# Altium schematic sheet PNPController.SchDoc(PNPController)
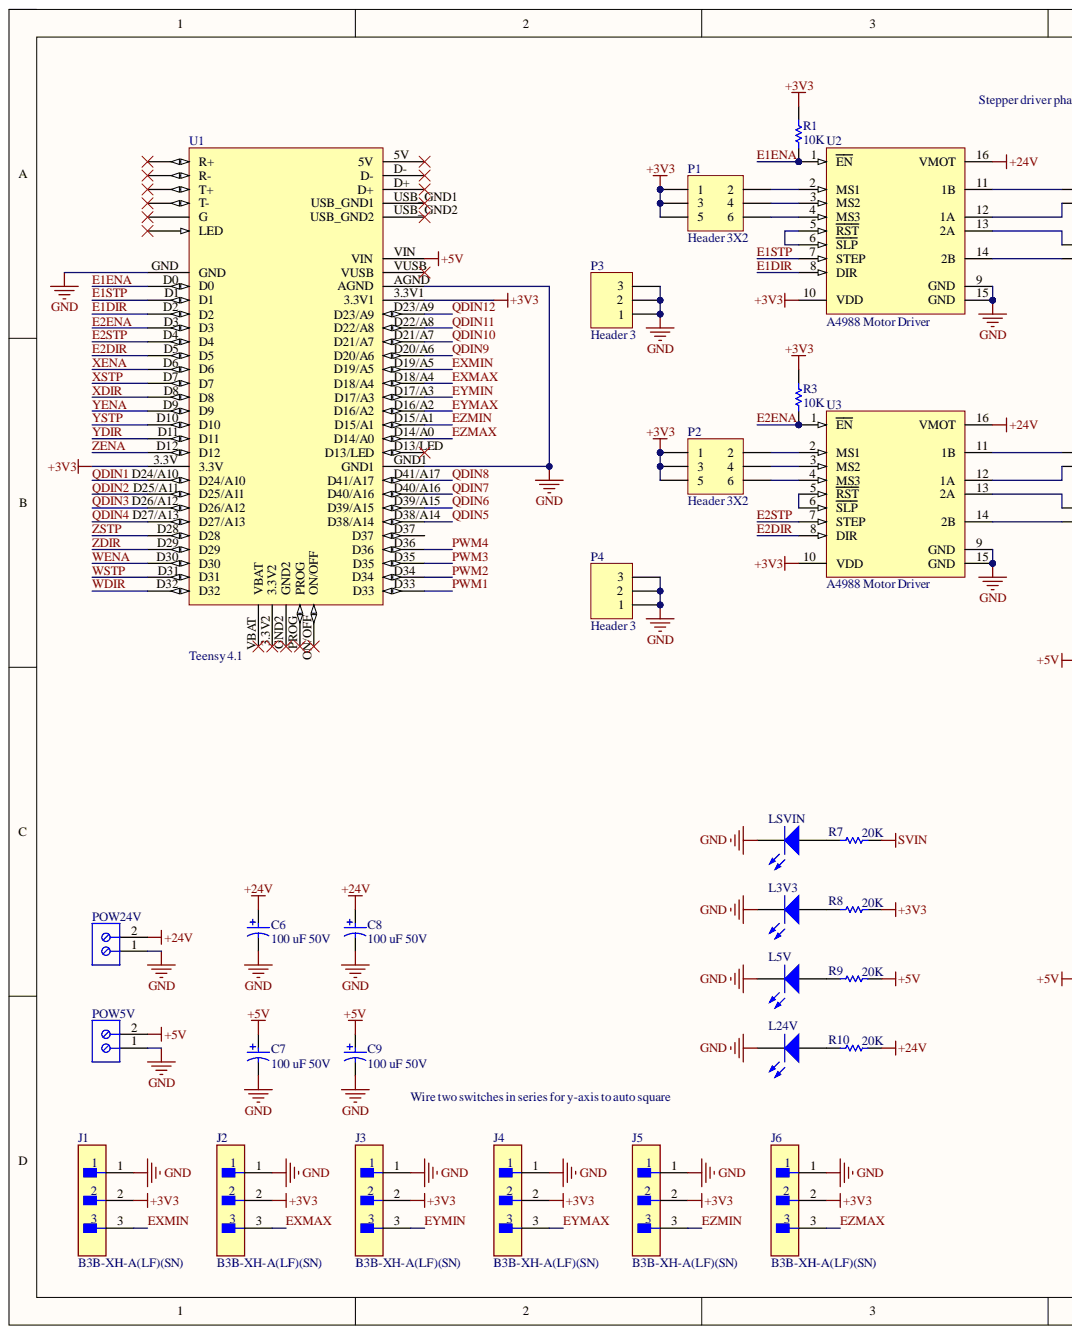
[diagram p0: PNPController.SchDoc, left]
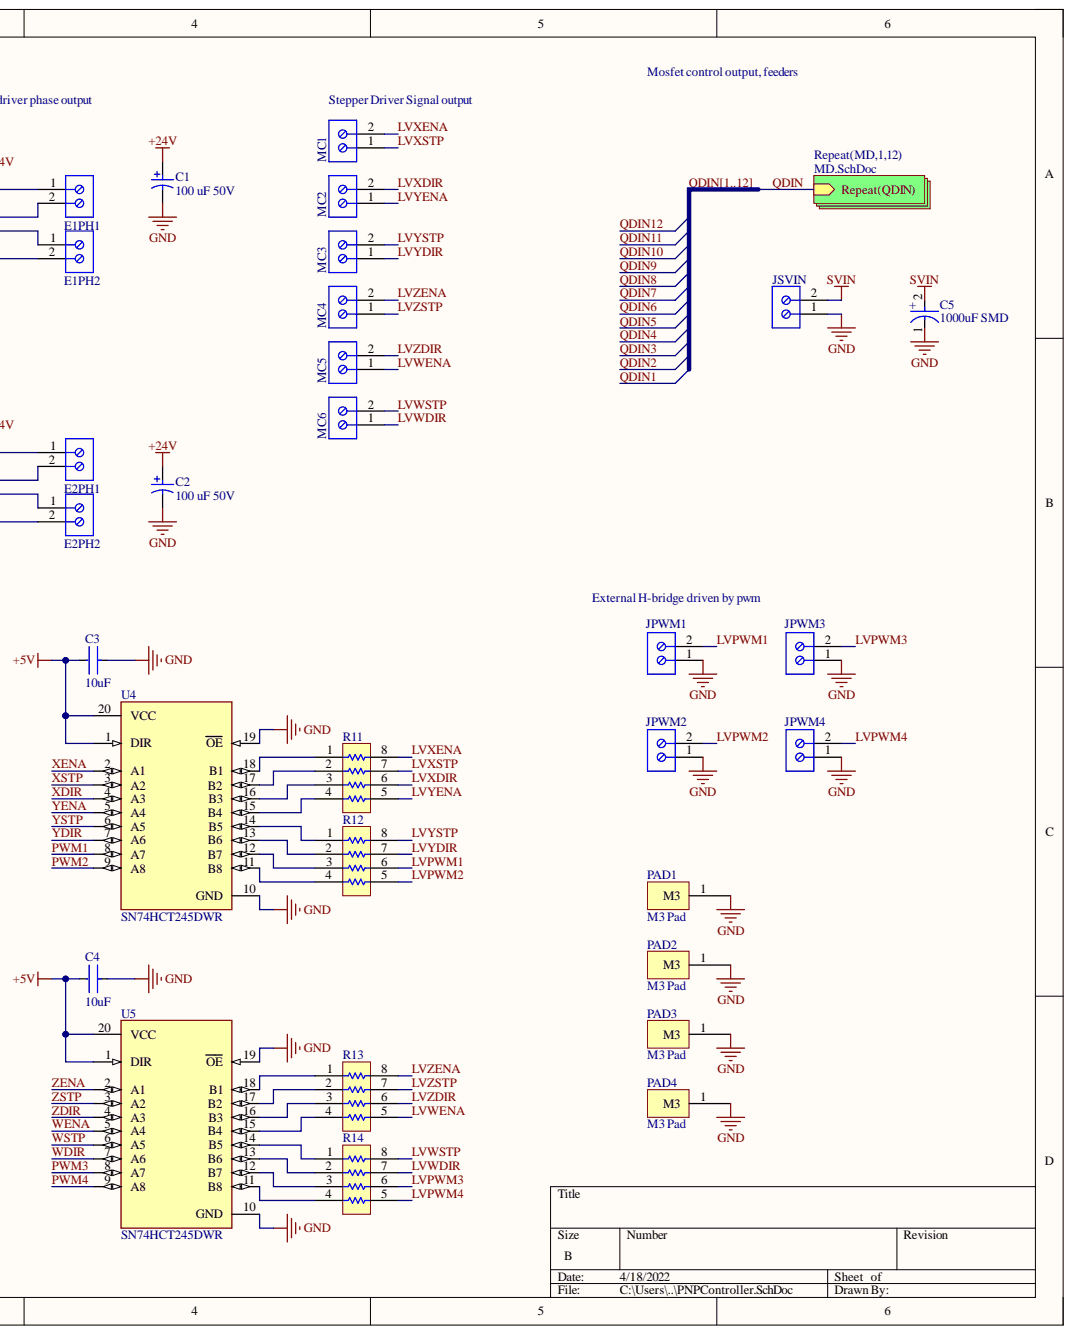
[diagram p1: PNPController.SchDoc, right]

## Parts
C1  100 uF 50V  [NIC-UWT1H101MNL1GS_L]
C2  100 uF 50V  [NIC-UWT1H101MNL1GS_L]
C3  value 10uF  [FP-CC0805-0_85-MFG]  (hidden comment: CC0805KKX7R6BB105)
C4  value 10uF  [FP-CC0805-0_85-MFG]  (hidden comment: CC0805KKX7R6BB105)
C5  1000uF SMD  value 1000uF  Capacitance: 1000uF  [FP-FK-J16-MFG]
C6  100 uF 50V  [NIC-UWT1H101MNL1GS_L]
C7  100 uF 50V  [NIC-UWT1H101MNL1GS_L]
C8  100 uF 50V  Rated Voltage: 50V  [NIC-UWT1H101MNL1GS_L]
C9  100 uF 50V  Rated Voltage: 50V  [NIC-UWT1H101MNL1GS_L]
E1PH1  Screw Terminal Block 2 Position  [TECO-282836-2_V]  (value not drawn)
E1PH2  Screw Terminal Block 2 Position  [TECO-282836-2_V]  (value not drawn)
E2PH1  Screw Terminal Block 2 Position  [TECO-282836-2_V]  (value not drawn)
E2PH2  Screw Terminal Block 2 Position  [TECO-282836-2_V]  (value not drawn)
J1  B3B-XH-A(LF)(SN)  [FP-B3B-XH-A_LF_SN-MFG]
J2  B3B-XH-A(LF)(SN)  [FP-B3B-XH-A_LF_SN-MFG]
J3  B3B-XH-A(LF)(SN)  [FP-B3B-XH-A_LF_SN-MFG]
J4  B3B-XH-A(LF)(SN)  [FP-B3B-XH-A_LF_SN-MFG]
J5  B3B-XH-A(LF)(SN)  [FP-B3B-XH-A_LF_SN-MFG]
J6  B3B-XH-A(LF)(SN)  [FP-B3B-XH-A_LF_SN-MFG]
JPWM1  Screw Terminal Block 2 Position  [TECO-282836-2_V]  (value not drawn)
JPWM2  Screw Terminal Block 2 Position  [TECO-282836-2_V]  (value not drawn)
JPWM3  Screw Terminal Block 2 Position  [TECO-282836-2_V]  (value not drawn)
JPWM4  Screw Terminal Block 2 Position  [TECO-282836-2_V]  (value not drawn)
JSVIN  Screw Terminal Block 2 Position  [TECO-282836-2_V]  (value not drawn)
L3V3  150080SS75000  [0805_A]  (value not drawn)
L5V  150080SS75000  [0805_A]  (value not drawn)
L24V  150080SS75000  [0805_A]  (value not drawn)
LSVIN  150080SS75000  [0805_A]  (value not drawn)
MC1  Screw Terminal Block 2 Position  [TECO-282836-2_V]  (value not drawn)
MC2  Screw Terminal Block 2 Position  [TECO-282836-2_V]  (value not drawn)
MC3  Screw Terminal Block 2 Position  [TECO-282836-2_V]  (value not drawn)
MC4  Screw Terminal Block 2 Position  [TECO-282836-2_V]  (value not drawn)
MC5  Screw Terminal Block 2 Position  [TECO-282836-2_V]  (value not drawn)
MC6  Screw Terminal Block 2 Position  [TECO-282836-2_V]  (value not drawn)
P1  Header 3X2  [HDR2X3]
P2  Header 3X2  [HDR2X3]
P3  Header 3  [HDR1X3]
P4  Header 3  [HDR1X3]
PAD1  M3 Pad  [M3 Pad-Footprint-1]
PAD2  M3 Pad  [M3 Pad-Footprint-1]
PAD3  M3 Pad  [M3 Pad-Footprint-1]
PAD4  M3 Pad  [M3 Pad-Footprint-1]
POW5V  Screw Terminal Block 2 Position  [TECO-282836-2_V]  (value not drawn)
POW24V  Screw Terminal Block 2 Position  [TECO-282836-2_V]  (value not drawn)
R1  value 10K  [RESC2013X50X30LL20T20]  (hidden comment: CRCW08050000Z0EA)
R3  value 10K  [RESC2013X50X30LL20T20]  (hidden comment: CRCW08050000Z0EA)
R7  value 20K  [RESC2013X50X30LL20T20]  (hidden comment: CRCW08050000Z0EA)
R8  value 20K  [RESC2013X50X30LL20T20]  (hidden comment: CRCW08050000Z0EA)
R9  value 20K  [RESC2013X50X30LL20T20]  (hidden comment: CRCW08050000Z0EA)
R10  value 20K  [RESC2013X50X30LL20T20]  (hidden comment: CRCW08050000Z0EA)
R11  CAT16-103J4LF  [BOUR-CAT16-103J4LF_L]  (value not drawn)
R12  CAT16-103J4LF  [BOUR-CAT16-103J4LF_L]  (value not drawn)
R13  CAT16-103J4LF  [BOUR-CAT16-103J4LF_L]  (value not drawn)
R14  CAT16-103J4LF  [BOUR-CAT16-103J4LF_L]  (value not drawn)
U1  Teensy 4.1  [DEV-16771]
U2  A4988 Motor Driver  [Polo-A4988 Motor Driver_V]
U3  A4988 Motor Driver  [Polo-A4988 Motor Driver_V]
U4  SN74HCT245DWR  [FP-DW0020A-MFG]
U5  SN74HCT245DWR  [FP-DW0020A-MFG]

## Nets
+3V3: J1.2 J2.2 J3.2 J4.2 J5.2 J6.2 P1.1 P1.3 P1.5 P2.1 P2.3 P2.5 R1.2 R3.2 R8.2 U1.3.3V U1.3.3V1 U2.10(VDD) U3.10(VDD)
+5V: C3.2 C4.2 C7.1 C9.1 POW5V.2 R9.2 U1.VIN U4.1(DIR) U4.20(VCC) U5.1(DIR) U5.20(VCC)
+24V: C1.1 C2.1 C6.1 C8.1 POW24V.2 R10.2 U2.16(VMOT) U3.16(VMOT)
GND: C1.2 C2.2 C3.1 C4.1 C5.1 C6.2 C7.2 C8.2 C9.2 J1.1 J2.1 J3.1 J4.1 J5.1 J6.1 JPWM1.1 JPWM2.1 JPWM3.1 JPWM4.1 JSVIN.1 L3V3.1 L5V.1 L24V.1 LSVIN.1 P3.1 P3.2 P3.3 P4.1 P4.2 P4.3 PAD1.1 PAD2.1 PAD3.1 PAD4.1 POW5V.1 POW24V.1 U1.AGND U1.GND U1.GND1 U2.9(GND) U2.15(GND) U3.9(GND) U3.15(GND) U4.10(GND) U4.19(OE) U5.10(GND) U5.19(OE)
SVIN: C5.2 JSVIN.2 R7.2
E1DIR: U1.D2 U2.8(DIR)
E1ENA: R1.1 U1.D0 U2.1(EN)
E1STP: U1.D1 U2.7(STEP)
E2DIR: U1.D5 U3.8(DIR)
E2ENA: R3.1 U1.D3 U3.1(EN)
E2STP: U1.D4 U3.7(STEP)
EXMAX: J2.3 U1.D18/A4
EXMIN: J1.3 U1.D19/A5
EYMAX: J4.3 U1.D16/A2
EYMIN: J3.3 U1.D17/A3
EZMAX: J6.3 U1.D14/A0
EZMIN: J5.3 U1.D15/A1
LVPWM1: JPWM1.2 R12.6
LVPWM2: JPWM2.2 R12.5
LVPWM3: JPWM3.2 R14.6
LVPWM4: JPWM4.2 R14.5
LVWDIR: MC6.1 R14.7
LVWENA: MC5.1 R13.5
LVWSTP: MC6.2 R14.8
LVXDIR: MC2.2 R11.6
LVXENA: MC1.2 R11.8
LVXSTP: MC1.1 R11.7
LVYDIR: MC3.1 R12.7
LVYENA: MC2.1 R11.5
LVYSTP: MC3.2 R12.8
LVZDIR: MC5.2 R13.6
LVZENA: MC4.2 R13.8
LVZSTP: MC4.1 R13.7
PWM1: U1.D33 U4.8(A7)
PWM2: U1.D34 U4.9(A8)
PWM3: U1.D35 U5.8(A7)
PWM4: U1.D36 U5.9(A8)
QDIN1: U1.D24/A10  [also labelled QDIN]
QDIN2: U1.D25/A11  [also labelled QDIN]
QDIN3: U1.D26/A12  [also labelled QDIN]
QDIN4: U1.D27/A13  [also labelled QDIN]
QDIN5: U1.D38/A14  [also labelled QDIN]
QDIN6: U1.D39/A15  [also labelled QDIN]
QDIN7: U1.D40/A16  [also labelled QDIN]
QDIN8: U1.D41/A17  [also labelled QDIN]
QDIN9: U1.D20/A6  [also labelled QDIN]
QDIN10: U1.D21/A7  [also labelled QDIN]
QDIN11: U1.D22/A8  [also labelled QDIN]
QDIN12: U1.D23/A9  [also labelled QDIN]
WDIR: U1.D32 U5.7(A6)
WENA: U1.D30 U5.5(A4)
WSTP: U1.D31 U5.6(A5)
XDIR: U1.D8 U4.4(A3)
XENA: U1.D6 U4.2(A1)
XSTP: U1.D7 U4.3(A2)
YDIR: U1.D11 U4.7(A6)
YENA: U1.D9 U4.5(A4)
YSTP: U1.D10 U4.6(A5)
ZDIR: U1.D29 U5.4(A3)
ZENA: U1.D12 U5.2(A1)
ZSTP: U1.D28 U5.3(A2)
NetE1PH1_1: E1PH1.1 U2.11(1B)
NetE1PH1_2: E1PH1.2 U2.12(1A)
NetE1PH2_1: E1PH2.1 U2.13(2A)
NetE1PH2_2: E1PH2.2 U2.14(2B)
NetE2PH1_1: E2PH1.1 U3.11(1B)
NetE2PH1_2: E2PH1.2 U3.12(1A)
NetE2PH2_1: E2PH2.1 U3.13(2A)
NetE2PH2_2: E2PH2.2 U3.14(2B)
NetL3V3_2: L3V3.2 R8.1
NetL5V_2: L5V.2 R9.1
NetL24V_2: L24V.2 R10.1
NetLSVIN_2: LSVIN.2 R7.1
NetP1_2: P1.2 U2.2(MS1)
NetP1_4: P1.4 U2.3(MS2)
NetP1_6: P1.6 U2.4(MS3)
NetP2_2: P2.2 U3.2(MS1)
NetP2_4: P2.4 U3.3(MS2)
NetP2_6: P2.6 U3.4(MS3)
NetR11_1: R11.1 U4.18(B1)
NetR11_2: R11.2 U4.17(B2)
NetR11_3: R11.3 U4.16(B3)
NetR11_4: R11.4 U4.15(B4)
NetR12_1: R12.1 U4.14(B5)
NetR12_2: R12.2 U4.13(B6)
NetR12_3: R12.3 U4.12(B7)
NetR12_4: R12.4 U4.11(B8)
NetR13_1: R13.1 U5.18(B1)
NetR13_2: R13.2 U5.17(B2)
NetR13_3: R13.3 U5.16(B3)
NetR13_4: R13.4 U5.15(B4)
NetR14_1: R14.1 U5.14(B5)
NetR14_2: R14.2 U5.13(B6)
NetR14_3: R14.3 U5.12(B7)
NetR14_4: R14.4 U5.11(B8)
NetU1_3.3V2: U1.3.3V2
NetU1_5V: U1.5V
NetU1_D13/LED: U1.D13/LED
NetU1_D37: U1.D37
NetU1_D+: U1.D+
NetU1_D-: U1.D-
NetU1_G: U1.G
NetU1_GND2: U1.GND2
NetU1_LED: U1.LED
NetU1_ON/OFF: U1.ON/OFF
NetU1_PROG: U1.PROG
NetU1_R+: U1.R+
NetU1_R-: U1.R-
NetU1_T+: U1.T+
NetU1_T-: U1.T-
NetU1_USB_GND1: U1.USB_GND1
NetU1_USB_GND2: U1.USB_GND2
NetU1_VBAT: U1.VBAT
NetU1_VUSB: U1.VUSB
NetU2_5: U2.5(RST) U2.6(SLP)
NetU3_5: U3.5(RST) U3.6(SLP)

## Library data of the parts
C1 C2 C6-C9 = CMP-2000-07373-1: Aluminum Electrolytic Capacitor, 100 uF, +/- 20%, 50 V, -55 to 105 degC, 2-Pin SMD, RoHS, Tape and Reel; Manufacturer: Nichicon; Capacitance: 100µF; Type: Can; Case Size/Dimension: Ø8x10mm; Component Kind: Standard; Datasheet URL: http://products.nichicon.co.jp/en/pdf/XJA043/e-uwt.pdf; Datasheet Version: 08/2015; Library Name: Altium Content Vault; Manufacturer URL: http://www.nichicon.co.jp/english/; Mounting Technology: SurfaceMount; Operating Temp-Max: 105°C; Package Description: Aluminum Electrolytic Capacitor, Body 8.3 x 8.3 mm, Height 10.8 mm; Package Reference: UWT1H101MNL1GS
  C1 C2 C6 C7: Rated Voltage: 50V
C3 C4 = CMP-03422-000182-1: CAP CER 1UF 10V X7R 0805; Manufacturer: Yageo; Material: Ceramic; Tolerance: 0.1; Automotive: No; Capacitance: 1 uF; Category: Cap; Component Kind: Standard; ComponentLink2Description: Datasheet; ComponentLink2URL: http://www.yageo.com/documents/recent/UPY-GPHC_Y5V_6.3V-to-50V_8.pdf; Device Class L1: Passive Components; Device Class L2: Capacitors; Device Class L3: Ceramic Capacitors; Digikey Description: CAP CER 1UF 10V X7R 0805; Footprint Url: http://www.yageo.com/exep/pages/download/literatures/UPY-C_GEN_24.pdf; Height: 0.95mm; Ipc Land Pattern Name: CAPC200125X85; Lead Free: Yes; Library Name: Altium Content Vault; Manufacturer Part Number: CC0805KKX7R6BB105; Mouser Description: Multilayer Ceramic Capacitors MLCC - SMD/SMT 1.0uF 10V X7R 10%; Octopart: https://octopart.com/cc0805kkx7r6bb105-yageo-42522859; Package: CC0805-0.85; RoHS: TRUE; Temperature: -30°C to +85°C; Temperature Characteristic: X7R; Temperature Range High: +85°C; Temperature Range Low: -30°C; Voltage Rating: 10 V
C5 = CMP-05427-000011-1: CAP ALUM 1000UF 20% 50V SMD; Manufacturer: Panasonic Electronic Components; Manufacturer Part Number: EEV-FK1H102M; Automotive Grade: Grade 2; Dissipation Factor: 10%; Esr: 0.073Ω; Leakage Current: 500uA; Life: 2000Hours; Material: Aluminum; Ripple Current: 1610mA; Automotive: Yes; Category: Cap; Component Kind: Standard; ComponentLink2Description: Datasheet; ComponentLink2URL: https://b2b-api.panasonic.eu/file_stream/pids/fileversion/3127; Device Class L1: Passive Components; Device Class L2: Capacitors; Device Class L3: Aluminum Electrolytic Capacitors; Digikey Description: CAP ALUM 1000UF 20% 50V SMD; Height: 17mm; Lead Free: Yes; Library Name: Altium Content Vault; Mouser Description: Cap Aluminum Lytic 1000uF 50V 20% (16 X 16.5mm) SMD 0.073 Ohm 1610mA 2000h 105C Automotive T/R; Octopart: https://octopart.com/eev-fk1h102m-panasonic-39480688; Package: FK-J16; RoHS: TRUE; Temperature: -55°C to +105°C; Temperature Range High: +105°C; Temperature Range Low: -55°C; Tolerance: 20%; Voltage Rating: 50V
E1PH1 E1PH2 E2PH1 E2PH2 JPWM1-JPWM4 JSVIN MC1-MC6 POW5V POW24V = CMP-002-00072-1: TERM BLK 2POS SIDE ENTRY 5MM PCB; Approvals: UL; Closed End: No (Without); Connector Type: Receptacle; Current: 13.5A; Interlock: Yes (With); Max Supply Voltage (AC): 300V; Number of Circuits: 2; Number of Levels: 1; Plating: Brass; Tail Length: 3.5mm; Thread Size: M3; Wire Gauge (Max): 30AWG; Color: Green; Component Kind: Standard; Contact Material: Brass; Contact Plating: Tin; Current Rating: 13.5A; Depth: 8.2mm; ELV: Compliant; Flammability Rating: UL94 V-0; Housing Color: Green; Housing Material: Polyamide; Insulation Material: Nylon; Lead Free: Lead Free; Length: 10.6mm; Max Current Rating: 13.5A; Max Voltage Rating (AC): 300V; Mount: Through Hole; Number of Contacts: 2; Number of Poles: 2; Number of Positions: 2; Number of Positions Per Level: 2; Number of Rows: 1; Orientation: Straight; Package Quantity: 300; Packaging: Tray; Pitch: 5mm; Radiation Hardening: No; REACH SVHC: Unknown; Screw Flange: No (Without); Screwless Terminal Block: No (Without); Series: Buchanan; Side Stackable: Yes (With); Termination: Solder; Voltage: 300V; Voltage Rating: 300V; Width: 10mm; Wire Gauge (Min): 30AWG; Wire/Cable Gauge: 30AWG; Library Name: Gannon University
J1-J6 = CMP-17439-000014-2: CONN HEADER VERT 3POS 2.5MM; Contact Resistance: 20mR; Current Rating: 3A; Gender: Male; Insulation Resistance: 1GR; Max Voltage Rating (AC): 250V; Number of Contacts: 3; Wire/Cable Gauge: 22AWG; Color: Natural; Component Kind: Standard; Connector Type: Header; Contact Finish - Mating: TIN; Contact Material: Brass; Contact Plating: Tin; Contact Shape: Square; Contact Type: Male Pin; Depth: 5.75mm; Fastening Type: Detent; Flammability Rating: UL94 V-0; Housing Color: White; Housing Material: Polyamide; Insulation Color: Natural; Insulation Material: Nylon; Lead Free: Lead Free; Lead Pitch: 2.5mm; Length: 9.9mm; Library Name: Altium Content Vault; Max Current Rating: 3A; Max Operating Temperature: 85°C; Max Voltage Rating (DC): 250V; Min Operating Temperature: -25°C; Mount: Through Hole; Number of Positions: 3; Number of Rows: 1; Orientation: Straight; Packaging: Bulk; Pitch: 2.5mm; REACH SVHC: No SVHC; Series: XH; Termination: Solder; Voltage Rating: 250V; Voltage Rating (AC): 250V
L3V3 L5V L24V LSVIN = CMP-1426-00010-1: SMD mono-color Chip LED, WL-SMCW, Super Red; Manufacturer: Wuerth Elektronik; Color: Super Red; Component Kind: Standard; ComponentLink1Description: Manufacturer URL; ComponentLink1URL: http://www.we-online.com; ComponentLink2Description: Datasheet; ComponentLink2URL: http://katalog.we-online.com/led/datasheet/150080SS75000.pdf; Library Name: Altium Content Vault; PackageReference: 0805_A; PartNumber: 150080SS75000
P1 P2 = Header 3X2: Header, 3-Pin, Dual row; Component Kind: Standard; LatestRevisionDate: 17-Jul-2002; LatestRevisionNote: Re-released for DXP Platform.; Library Name: Miscellaneous Connectors.IntLib; Signal Integrity: Connector; Publisher: Altium Limited
P3 P4 = Header 3: Header, 3-Pin; Component Kind: Standard; LatestRevisionDate: 17-Jul-2002; LatestRevisionNote: Re-released for DXP Platform.; Library Name: Miscellaneous Connectors.IntLib; Signal Integrity: Connector; Publisher: Altium Limited
PAD1-PAD4 = CMP-000005-2: Through-hole pad for M3 bolts; Component Kind: Standard; Library Name: Gannon University
R1 R3 R7-R10 = CMP-2001-04896-1: Manufacturer: Vishay Dale; Component Kind: Standard; Library Name: Altium Content Vault; Part Number: CRCW08050000Z0EA
R11-R14 = CMP-2000-06067-1: CAT / CAY 16 Series - Chip Resistor Array, 10 KOhm, +/- 5%, 50 V, -55 to 125 degC, 8-Pin SMD, RoHS, Tape and Reel; Manufacturer: Bourns; Circuit Type: Isolated; EDA / CAD Models: DownloadfromAcceleratedDesigns; Number of Resistors: 4; Online Catalog: CAT16Series; Other Names: CAT16-103J4LFDKR; Power Per Element: 62.5mW; Resistance (Ohms): 10k; Temperature Coefficient: ±200ppm/°C; Applications: -; Category: Resistors; Component Kind: Standard; Datasheet URL: http://www.mouser.com/ds/2/54/ATCAY-777361.pdf; Datasheet Version: 09/2014; Family: ResistorNetworks,Arrays; Height: 0.024"(0.60mm); Library Name: Altium Content Vault; Manufacturer URL: http://www.bourns.com/; Mounting Technology: SurfaceMount; Mounting Type: SurfaceMount; Number of Pins: 8; Operating Temperature: -55°C~125°C; Package / Case: 1206(3216Metric),Concave,LongSideTerminals; Package Description: 8-Pin Surface Mount Device, Body 3.2 x 1.55 mm, Pitch 0.8 mm; Package Reference: CAT16-103J4LF; Packaging: Digi-Reel®; RoHS Information: CAT16MaterialDeclaration; Series: CAT16; Size / Dimension: 0.126"Lx0.061"W(3.20mmx1.55mm); Standard Package: 1; Supplier Device Package: 1206; Tolerance: ±5%
U1 = CMP-024-0000-4: RT1062 Teensy 4.1 i.MX ARMÂ® CortexÂ®-M7 MPU Embedded Evaluation Board; MF: SparkFun Electronics; Availability: Warning; BuyPart: https://www.snapeda.com/parts/DEV-16771/SparkFun%20Electronics/view-part/4833875/?ref=eda; Component Kind: Standard; Component Type: Standard; Design Item ID: DEV-16771; DigiKey-Purchase-URL: https://snapeda.com/shop?store=DigiKey&id=4833875; Mouser-Purchase-URL: https://snapeda.com/shop?store=Mouser&id=4833875; MP: DEV-16771; Package: None; Pin Count: 65; Price: None; SnapEDA_Link: https://www.snapeda.com/parts/DEV-16771/SparkFun%20Electronics/view-part/4833875/?ref=snap; Source: DEV-16771.SchLib; Library Name: Gannon University
U2 U3 = CMP-00024-00002-1: Stepper Motor Driver Carrier, 3 to 5.5 V, 2 A, 16-Pin THD; Manufacturer: Pololu Robotics & Electronics; Case/Package: A4988MotorDriver; Component Kind: Standard; Datasheet URL: https://www.pololu.com/file/0J1082/a4988-stepper-motor-driver-carrier-dimension-diagram.pdf; Datasheet Version: Dec-15; Mounting Technology: TH; Package Description: 16-Pin Through Hole Device, Body 20.3 x 15.2 mm, Pitch 2.54 mm; Package Reference: A4988 Motor Driver; RoHS Compliant: Unknown; Library Name: Gannon University
U4 U5 = CMP-1620-00207-3: IC TXRX NON-INVERT 5.5V 20SOIC; Manufacturer: Texas Instruments; Frequency: 25MHz; Logic Function Desc: Non-Inverting; Max Junction Temp: +150°C; Min Supply Voltage: 4.5V; Nominal Supply Current: 80uA; Number Of Circuits: 1; Number Of Inputs: 8; Propagation Delay: 18ns; Standoff Height: 0.1mm; Ambient Temperature Range High: +85°C; Ambient Temperature Range Low: -40°C; Automotive: No; Category: IC; Component Kind: Standard; ComponentLink2Description: Datasheet; ComponentLink2URL: http://www.ti.com/lit/ds/symlink/sn74hct245.pdf; Device Class L1: Integrated Circuits (ICs); Device Class L2: Logic ICs; Device Class L3: Drivers and Transceivers; Digikey Description: IC TXRX NON-INVERT 5.5V 20SOIC; Height: 2.65mm; Ipc Land Pattern Name: SOIC127P1030X265-20; Lead Free: Yes; Library Name: Altium Content Vault; Manufacturer Part Number: SN74HCT245DWR; Max Supply Voltage: 5.5V; Mouser Description: Octal Bus Transceivers With 3-State Outputs 20-SOIC -40 to 85; Number Of Outputs: 8; Octopart: https://octopart.com/sn74hct245dwr-texas+instruments-516824; Package: DW0020A; RoHS: TRUE; Temperature: -40°C to +85°C; Temperature Range High: +85°C; Temperature Range Low: -40°C
